# Altium schematic sheet power.SchDoc(power)
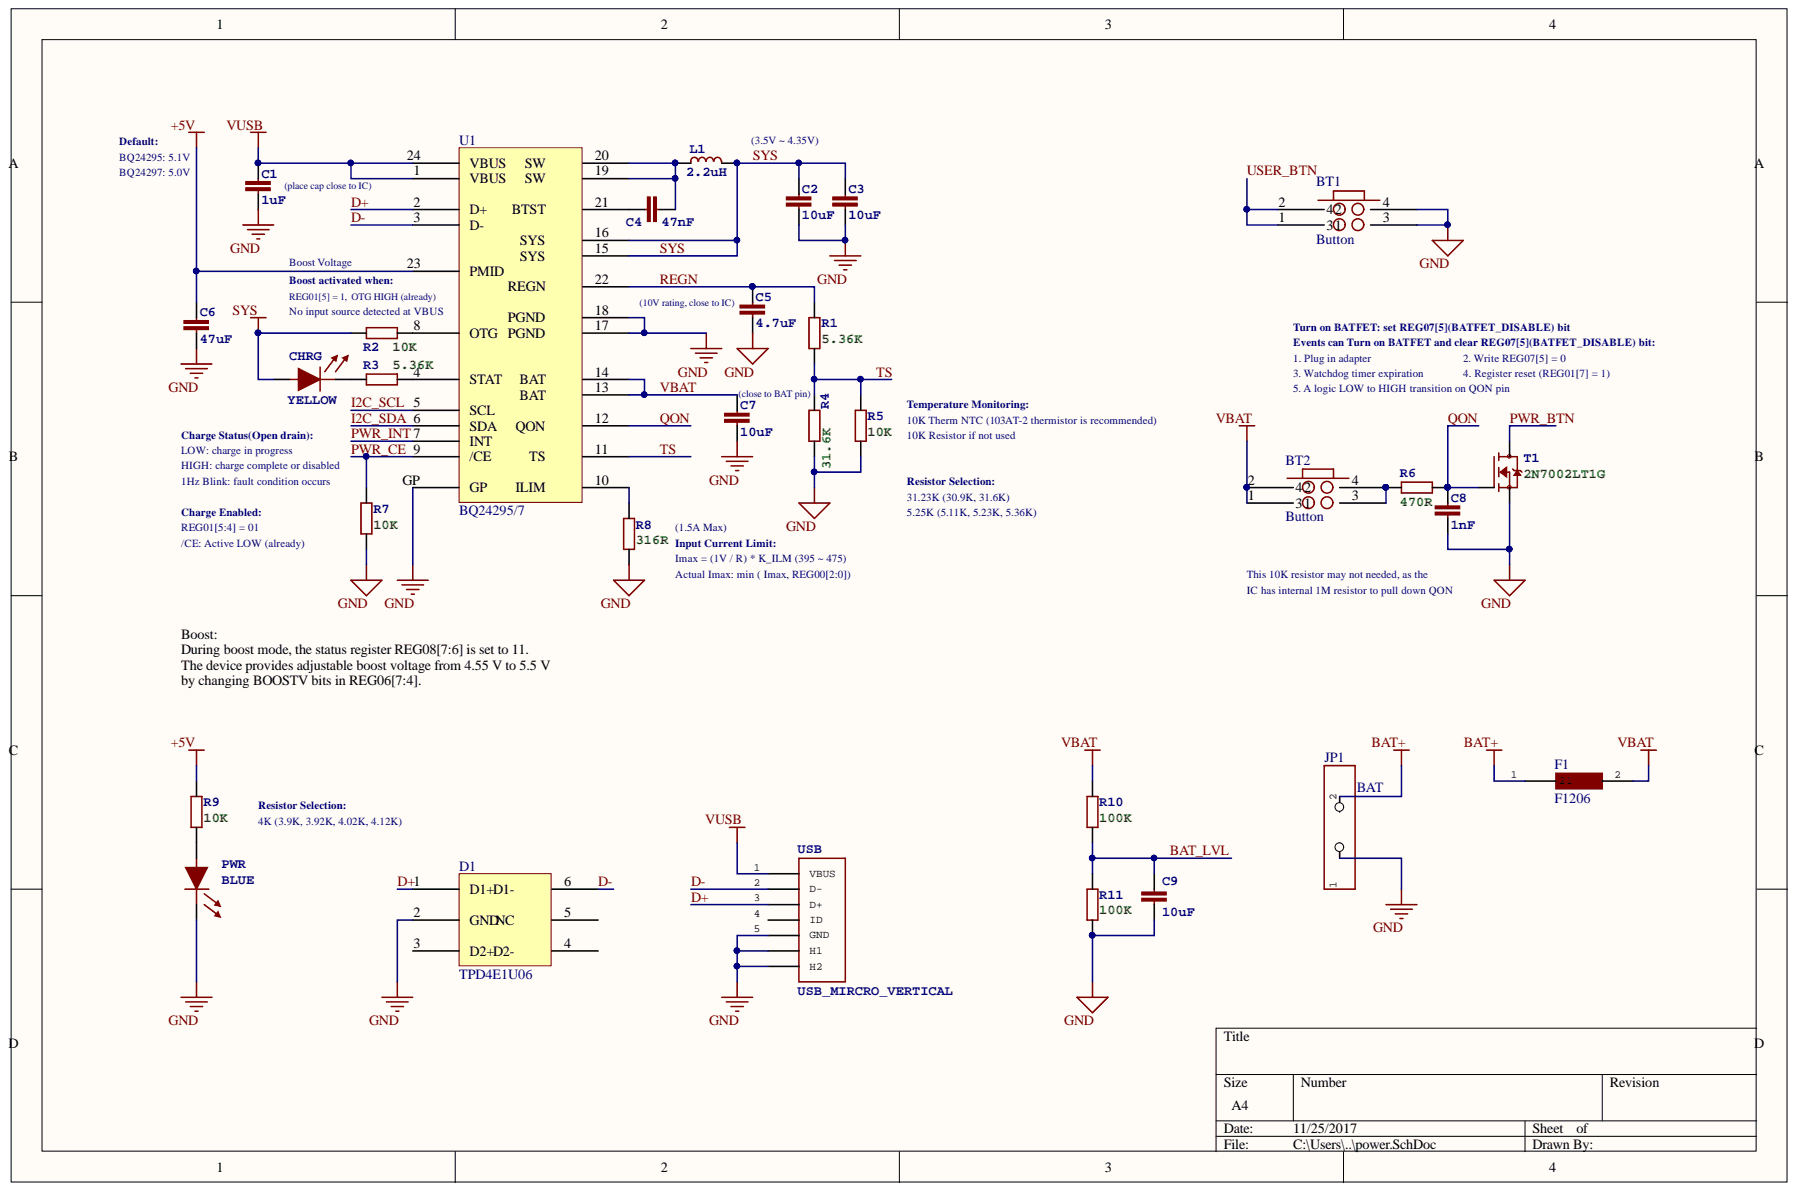
[diagram p0: power.SchDoc]

## Parts
BT1  Button  [BUTTON_SMT_4x4x1.5]
BT2  Button  [BUTTON_SMT_4x4x1.5]
C1  1uF  [C0603]
C2  10uF  [C0805]
C3  10uF  [C0805]
C4  47nF  [C0603]
C5  4.7uF  [C0603]
C6  47uF  [C0805]
C7  10uF  [C0603]
C8  1nF  [C0603]
C9  10uF  [C0603]
CHRG  YELLOW  [LED0603]
D1  TPD4E1U06  [SOT23-6]
F1  F1206  [_1206]
JP1  BAT  [DDBOT_PIN-1X2_2.0MM]
L1  2.2uH  [INDUCTOR_5X5MM_TDK_VLC5045]
PWR  BLUE  [LED0603]
R1  5.36K  [R0603]
R2  10K  [R0603]
R3  5.36K  [R0603]
R4  31.6K  [R0603]
R5  10K  [R0603]
R6  470R  [R0603]
R7  10K  [R0603]
R8  316R  [R0603]
R9  10K  [R0603]
R10  100K  [R0603]
R11  100K  [R0603]
T1  2N7002LT1G  [SOT-23]
U1  BQ24295/7  [QFN24-4X4MM_TI]
USB  USB_MIRCRO_VERTICAL  [USB_MICRO_VERTICAL]

## Nets
+5V: C6.1 R9.2 U1.23(PMID)
BAT+: F1.1 JP1.2
GND: BT1.3 BT1.4 C1.2 C2.2 C3.2 C5.2 C6.2 C7.2 C8.2 C9.2 D1.2(GND) JP1.1 PWR.1 R4.1 R5.1 R7.1 R8.1 R11.1 T1.2 U1.17 U1.18 U1.GP USB.5(GND) USB.H1 USB.H2
VUSB: C1.1 U1.1(VBUS) U1.24(VBUS) USB.1(VBUS)
BAT_LVL: C9.1 R10.1 R11.2
D+: D1.1(D1+_D1-) U1.2(D+) USB.3(D+)
D-: D1.6 U1.3(D-) USB.2(D-)
I2C_SCL: U1.5(SCL)
I2C_SDA: U1.6(SDA)
PWR_BTN: T1.1
PWR_CE: R7.2 U1.9(/CE)
PWR_INT: U1.7(INT)
QON: C8.1 R6.1 T1.3 U1.12
REGN: C5.1 R1.2 U1.22
SYS: C2.1 C3.1 CHRG.2 L1.P$2 R2.2 U1.15 U1.16
TS: R1.1 R4.2 R5.2 U1.11
USER_BTN: BT1.1(3_1) BT1.2(4_2)
VBAT: BT2.1(3_1) BT2.2(4_2) C7.1 F1.2 R10.2 U1.13 U1.14
NetBT2_3: BT2.3 BT2.4 R6.2
NetC4_1: C4.1 U1.21
NetC4_2: C4.2 L1.P$1 U1.19 U1.20
NetCHRG_1: CHRG.1 R3.2
NetPWR_2: PWR.2 R9.1
NetR2_1: R2.1 U1.8(OTG)
NetR3_1: R3.1 U1.4(STAT)
NetR8_2: R8.2 U1.10

Not connected: D1 3(D2+_D2-) 4 5; USB 4(ID)

## Library data of the parts
BT1 BT2 = BUTTON_4x4x1.5: Component Kind: Standard; Library Name: shenghua.SCHLIB
C1 C4 C5 C7-C9 = C-EUC0603: CAPACITOR, European symbol; Component Kind: Standard; Library Name: shenghua.SCHLIB
C2 C3 C6 = C-EUC0805: Component Kind: Standard; Library Name: shenghua.SCHLIB
CHRG PWR = LED0603: Component Kind: Standard; Library Name: shenghua.SCHLIB
JP1 = DDBOT_PIN_1X2-2.0MM: Component Kind: Standard; Library Name: shenghua.SCHLIB
L1 = INDUCTORTDK_VLC5045: Component Kind: Standard; Library Name: shenghua.SCHLIB
R1-R11 = R-EU_R0603: Component Kind: Standard; Library Name: shenghua.SCHLIB
T1 = 2N7002LT1G: 2N7002 60 V, 300 mA N-channel Trench MOSFET; Component Kind: Standard; Library Name: shenghua.SCHLIB
USB = USB_MIRCRO_VERTICAL: PART_NUMBER: MCR-B-S-RA-SMT-CS1TR; Component Kind: Standard; Library Name: shenghua.SCHLIB
D1 = TPD4E1U06: Component Kind: Standard; Library Name: shenghua.SCHLIB
F1 = F1206: Component Kind: Standard; Library Name: shenghua.SCHLIB
U1 = BQ24295/7: Component Kind: Standard; Library Name: shenghua.SCHLIB
